# Altium schematic sheet Click Mezzanine_v103.SchDoc("Click Mezzanine_v103")
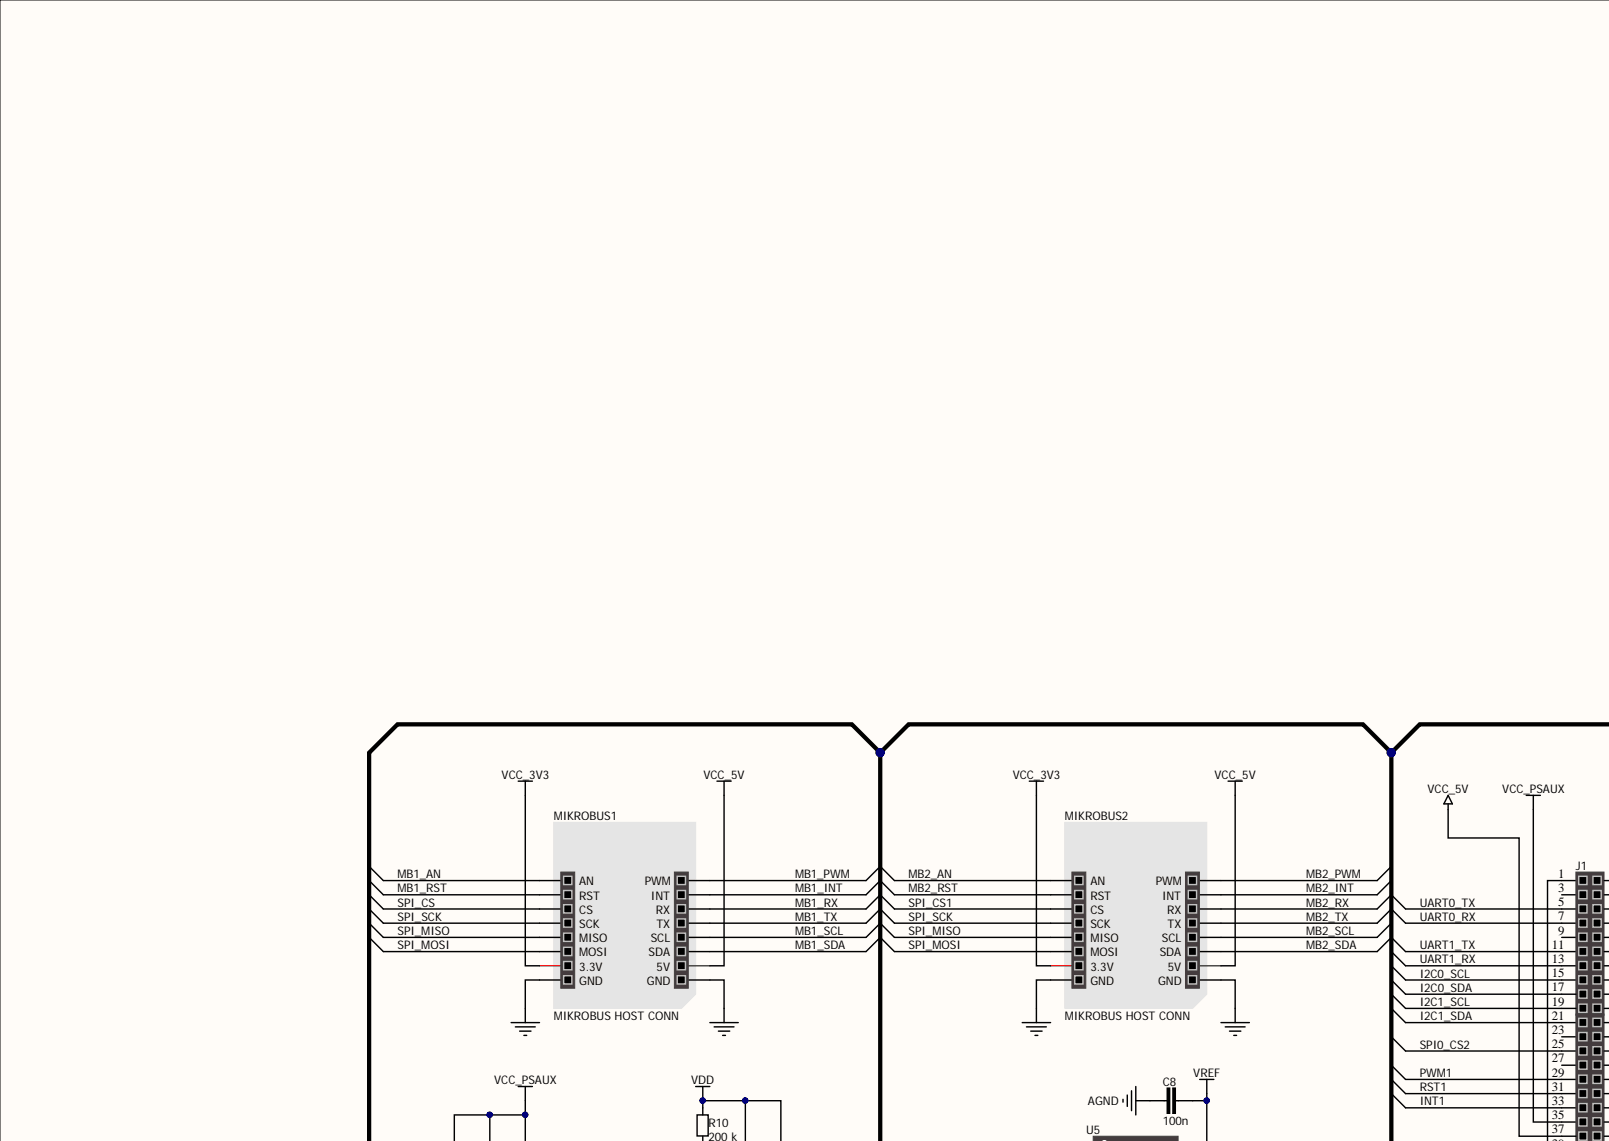
[diagram p0: Click Mezzanine_v103.SchDoc, top left]
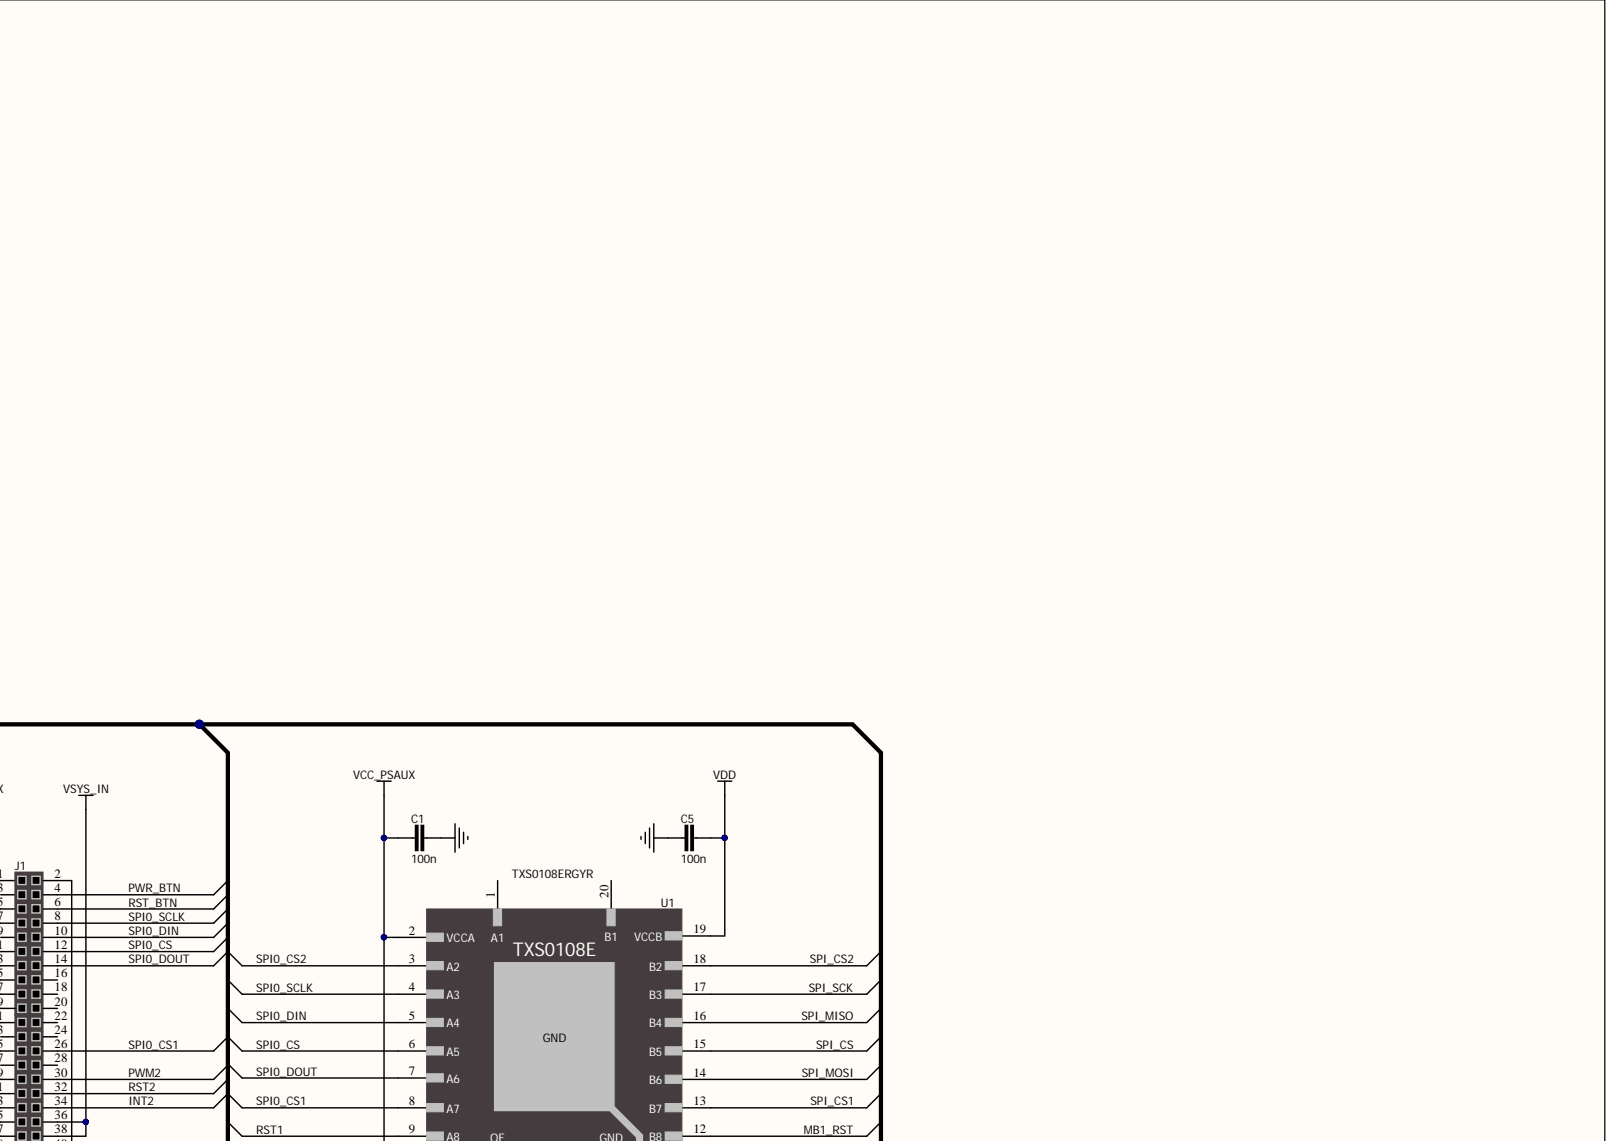
[diagram p1: Click Mezzanine_v103.SchDoc, top right]
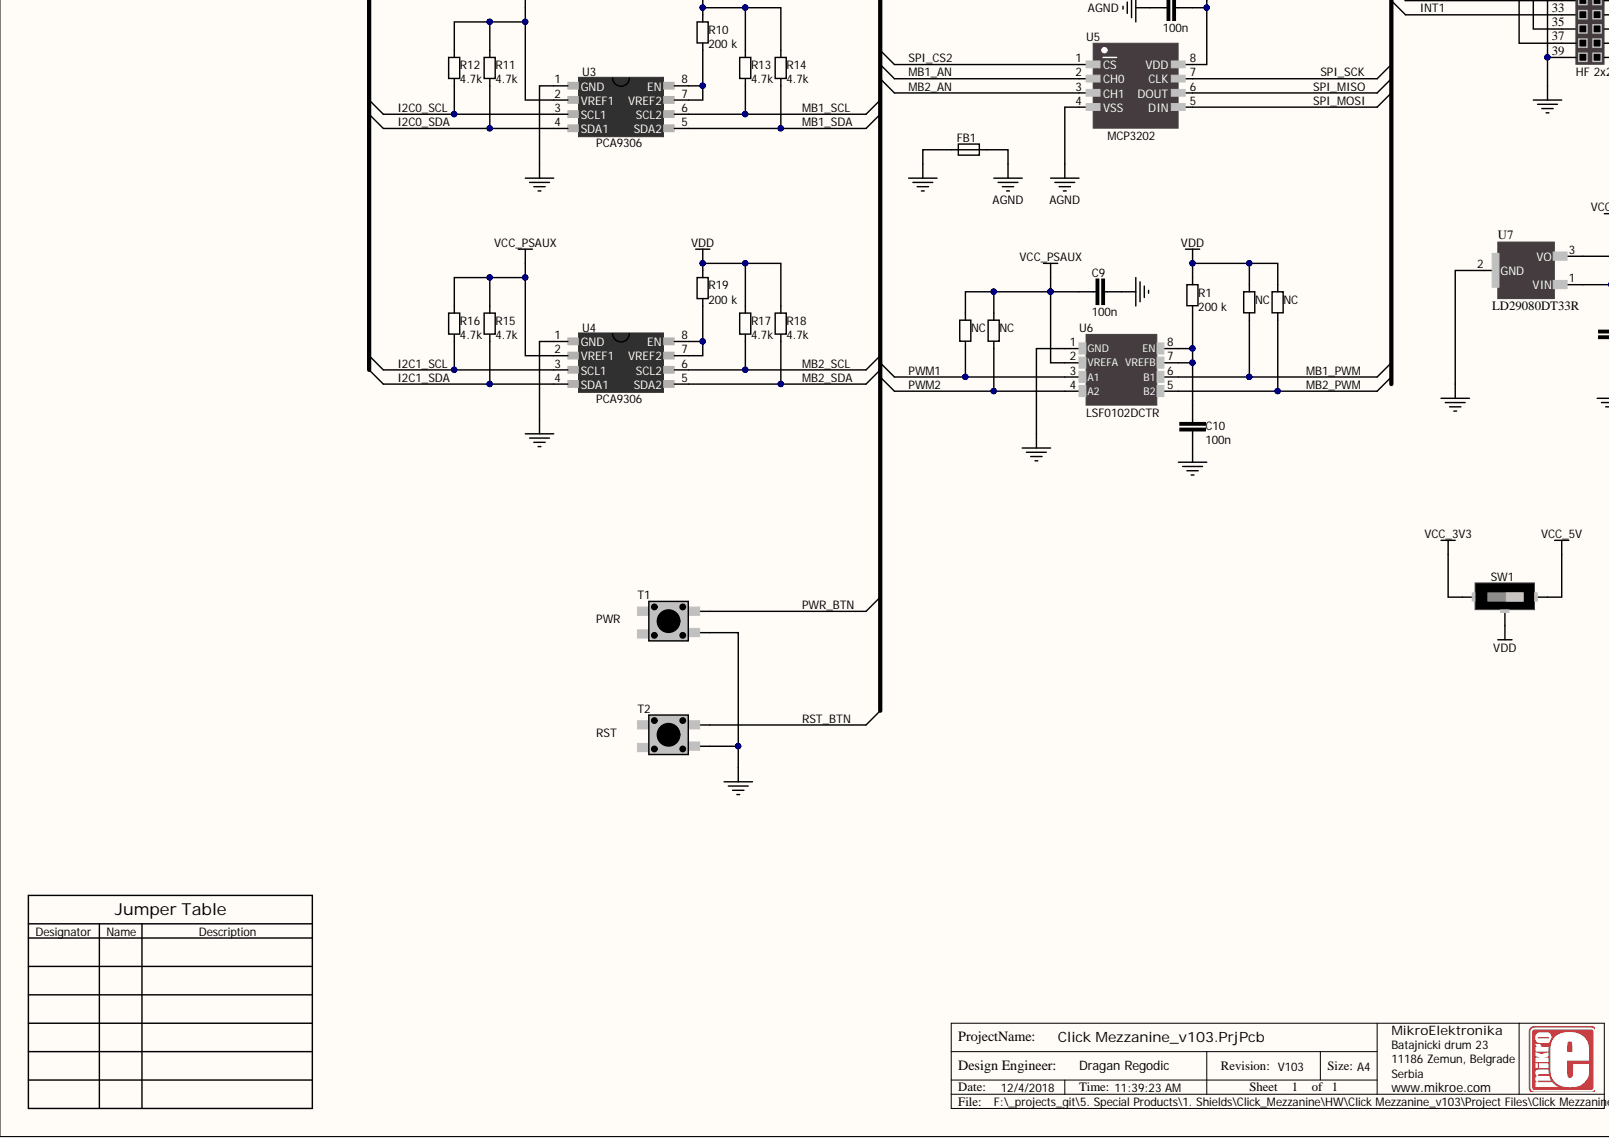
[diagram p2: Click Mezzanine_v103.SchDoc, bottom left]
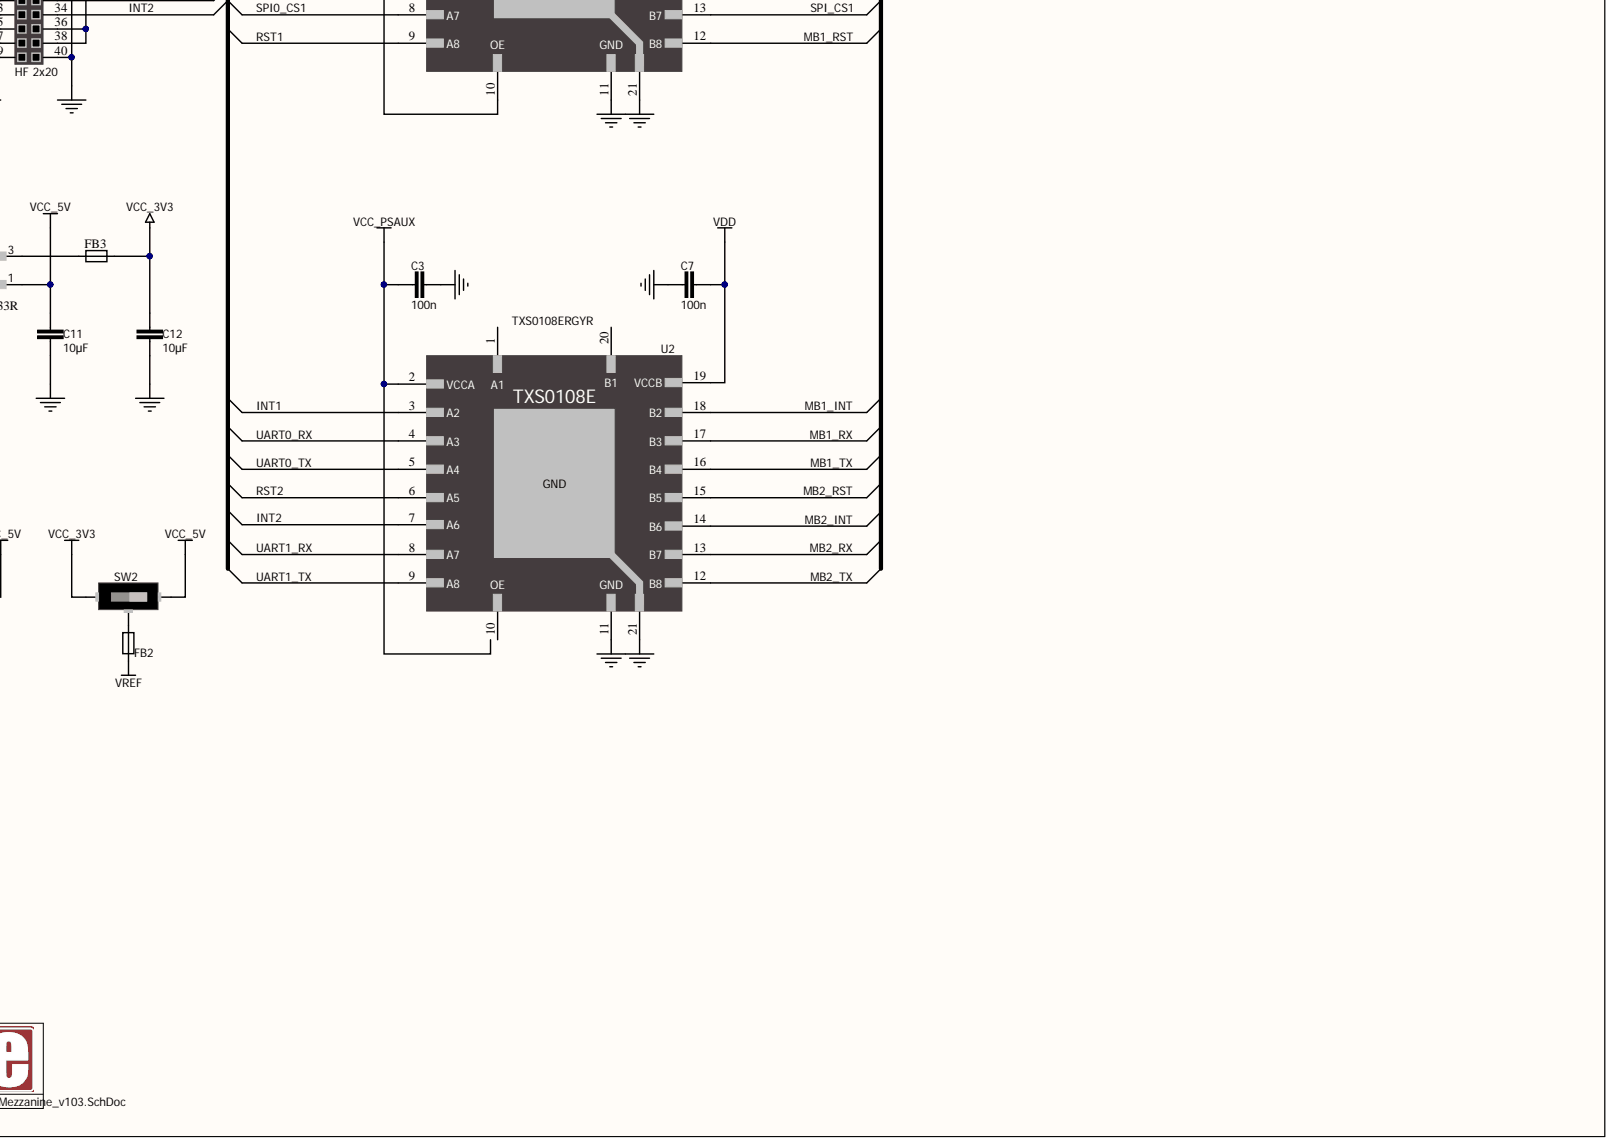
[diagram p3: Click Mezzanine_v103.SchDoc, bottom right]

## Parts
C1  100n  [CAPC2013X100N-0805-NoOverlay]
C3  100n  [CAPC2013X100N-0805-NoOverlay]
C5  100n  [CAPC2013X100N-0805-NoOverlay]
C7  100n  [CAPC2013X100N-0805-NoOverlay]
C8  100n  [CAPC2013X100N-0805-NoOverlay]
C9  100n  [CAPC2013X100N-0805-NoOverlay]
C10  100n  [CAPC2013X100N-0805-NoOverlay]
C11  10µF  [CAPC2013X100N-0805-NoOverlay]
C12  10µF  [CAPC2013X100N-0805-NoOverlay]
FB1  FERRITE  [INDC2213X140N-0805-NOOVERLAY]  (value not drawn)
FB2  FERRITE  [INDC2213X140N-0805-NOOVERLAY]  (value not drawn)
FB3  FERRITE  [INDC2213X140N-0805-NOOVERLAY]  (value not drawn)
J1  HF 2x20  Number of Positions: 2x20  [HF2X20-2MM-STACKING]
MIKROBUS1  MIKROBUS HOST CONN  [MIKROBUS HOST CONN. SMALL SMD V2a]  (no BOM)
MIKROBUS2  MIKROBUS HOST CONN  [MIKROBUS HOST CONN. SMALL SMD V2a]  (no BOM)
R1  200 k  [RESC2013X38N-0805-NOOVERLAY]
R3  NC  [RESC2013X38N-0805-NOOVERLAY]  (no BOM)
R4  NC  [RESC2013X38N-0805-NOOVERLAY]  (no BOM)
R5  NC  [RESC2013X38N-0805-NOOVERLAY]  (no BOM)
R6  NC  [RESC2013X38N-0805-NOOVERLAY]  (no BOM)
R10  200 k  [RESC2013X38N-0805-NOOVERLAY]
R11  4.7k  [RESC2013X38N-0805-NOOVERLAY]
R12  4.7k  [RESC2013X38N-0805-NOOVERLAY]
R13  4.7k  [RESC2013X38N-0805-NOOVERLAY]
R14  4.7k  [RESC2013X38N-0805-NOOVERLAY]
R15  4.7k  [RESC2013X38N-0805-NOOVERLAY]
R16  4.7k  [RESC2013X38N-0805-NOOVERLAY]
R17  4.7k  [RESC2013X38N-0805-NOOVERLAY]
R18  4.7k  [RESC2013X38N-0805-NOOVERLAY]
R19  200 k  [RESC2013X38N-0805-NOOVERLAY]
SW1  CJS-1200TA  [CAS-120TA-NoOverlay]  (value not drawn)
SW2  CJS-1200TA  [CAS-120TA-NoOverlay]  (value not drawn)
T1  PWR  [KMR221NG LFS-NoOverlay]
T2  RST  [KMR221NG LFS-NoOverlay]
U1  TXS0108ERGYR  [QFN50P450X350X100-21_21T215X315N-VFQFN-20-NoOverlay]
U2  TXS0108ERGYR  [QFN50P450X350X100-21_21T215X315N-VFQFN-20-NoOverlay]
U3  PCA9306  [VFSOP-8 NO OVERLAY]
U4  PCA9306  [VFSOP-8 NO OVERLAY]
U5  MCP3202  [SOIC8P127_490X600X175L83X41N-SOIC8 - NoOverlay]
U6  LSF0102DCTR  [SOP65P490X110-8L30N-TSSOP-8-NoOverlay]
U7  LD29080DT33R  [TO230P950X240-3N-NoOverlay]

## Nets
AGND: C8.1 FB1.1 U5.4(VSS)
GND: C1.1 C3.1 C5.1 C7.1 C9.1 C10.2 C11.1 C12.1 FB1.2 J1.1 J1.2 J1.39 J1.40 MIKROBUS1.8(GND) MIKROBUS1.9(GND) MIKROBUS2.8(GND) MIKROBUS2.9(GND) T1.2 T2.2 U1.11 U1.21 U2.11 U2.21 U3.1(GND) U4.1(GND) U6.1(GND) U7.2
VCC_3V3: C12.2 FB3.1 MIKROBUS1.7(3.3V) MIKROBUS2.7(3.3V) SW1.3 SW2.3
VCC_5V: C11.2 J1.37 MIKROBUS1.10(5V) MIKROBUS2.10(5V) SW1.1 SW2.1 U7.1
VCC_PSAUX: C1.2 C3.2 C9.2 J1.35 R3.2 R4.2 R11.2 R12.2 R15.2 R16.2 U1.2(VCCA) U1.10(OE) U2.2(VCCA) U3.2(VREF1) U4.2(VREF1) U6.2(VREFA)
VDD: C5.2 C7.2 R1.2 R5.2 R6.2 R10.2 R13.2 R14.2 R17.2 R18.2 R19.2 SW1.2 U1.19(VCCB) U2.19(VCCB)
VREF: C8.2 FB2.2 U5.8(VDD)
VSYS_IN: J1.36 J1.38
I2C0_SCL: J1.15 R12.1 U3.3(SCL1)
I2C0_SDA: J1.17 R11.1 U3.4(SDA1)
I2C1_SCL: J1.19 R16.1 U4.3(SCL1)
I2C1_SDA: J1.21 R15.1 U4.4(SDA1)
INT1: J1.33 U2.3(A2)
INT2: J1.34 U2.7(A6)
MB1_AN: MIKROBUS1.1(AN) U5.2(CH0)
MB1_INT: MIKROBUS1.15(INT) U2.18(B2)
MB1_PWM: MIKROBUS1.16(PWM) R5.1 U6.6(B1)
MB1_RST: MIKROBUS1.2(RST) U1.12(B8)
MB1_RX: MIKROBUS1.14(RX) U2.17(B3)
MB1_SCL: MIKROBUS1.12(SCL) R13.1 U3.6(SCL2)
MB1_SDA: MIKROBUS1.11(SDA) R14.1 U3.5(SDA2)
MB1_TX: MIKROBUS1.13(TX) U2.16(B4)
MB2_AN: MIKROBUS2.1(AN) U5.3(CH1)
MB2_INT: MIKROBUS2.15(INT) U2.14(B6)
MB2_PWM: MIKROBUS2.16(PWM) R6.1 U6.5(B2)
MB2_RST: MIKROBUS2.2(RST) U2.15(B5)
MB2_RX: MIKROBUS2.14(RX) U2.13(B7)
MB2_SCL: MIKROBUS2.12(SCL) R17.1 U4.6(SCL2)
MB2_SDA: MIKROBUS2.11(SDA) R18.1 U4.5(SDA2)
MB2_TX: MIKROBUS2.13(TX) U2.12(B8)
PWM1: J1.29 R3.1 U6.3(A1)
PWM2: J1.30 R4.1 U6.4(A2)
PWR_BTN: J1.4 T1.1
RST1: J1.31 U1.9(A8)
RST2: J1.32 U2.6(A5)
RST_BTN: J1.6 T2.1
SPI0_CS: J1.12 U1.6(A5)
SPI0_CS1: J1.26 U1.8(A7)
SPI0_CS2: J1.25 U1.3(A2)
SPI0_DIN: J1.10 U1.5(A4)
SPI0_DOUT: J1.14 U1.7(A6)
SPI0_SCLK: J1.8 U1.4(A3)
SPI_CS: MIKROBUS1.3(CS) U1.15(B5)
SPI_CS1: MIKROBUS2.3(CS) U1.13(B7)
SPI_CS2: U1.18(B2) U5.1(CS)
SPI_MISO: MIKROBUS1.5(MISO) MIKROBUS2.5(MISO) U1.16(B4) U5.6(DOUT)
SPI_MOSI: MIKROBUS1.6(MOSI) MIKROBUS2.6(MOSI) U1.14(B6) U5.5(DIN)
SPI_SCK: MIKROBUS1.4(SCK) MIKROBUS2.4(SCK) U1.17(B3) U5.7(CLK)
UART0_RX: J1.7 U2.4(A3)
UART0_TX: J1.5 U2.5(A4)
UART1_RX: J1.13 U2.8(A7)
UART1_TX: J1.11 U2.9(A8)
NetC10_1: C10.1 R1.1 U6.7(VREFB) U6.8(EN)
NetFB2_1: FB2.1 SW2.2
NetFB3_2: FB3.2 U7.3
NetR10_1: R10.1 U3.7(VREF2) U3.8(EN)
NetR19_1: R19.1 U4.7(VREF2) U4.8(EN)
N00045:

Not connected: J1 3 9 16 18 20 22 23 24 27 28; U1 1(A1) 20(B1); U2 1(A1) 10(OE) 20(B1)

## Library data of the parts
C1 C3 C5 C7-C10 = 0100000001868: Manufacturer: Yageo; Manufacturer Part Number: CC0805KRX7R9BB104; Assembly: SMD; Database Table Name: capacitors; id: 136; item_id: 450; Recommended Rating: Platinum; Temperature Coefficient: X7R; Tolerance: 10%; Voltage Rated: 50; Barcode: 0100000001868; Capacitance: 100n; Category: Capacitors; Component Kind: Standard; Family: CERAMIC CAPACITOR; Library Name: ME-Altium Lib.SVNDbLib; Package Case: 0805; Part Type: Capacitors
C11 C12 = 0100000012963: CAP CER 10UF 25V X5R 0805; Manufacturer: Murata Electronics North America; Manufacturer Part Number: GRM21BR61E106KA73L; Assembly: SMD; Database Table Name: capacitors; id: 376; item_id: 1714; Recommended Rating: Platinum; Tolerance: ±10%; Barcode: 0100000012963; Capacitance: 10µF; Category: Capacitors; Component Kind: Standard; Datasheet URL: http://www.murata.com/~/media/webrenewal/support/library/catalog/products/capacitor/mlcc/c02e.ashx; Family: CERAMIC CAPACITOR; Library Name: ME-Altium Lib.SVNDbLib; Package Case: 0805 (2012 Metric); Part Type: Capacitors; Temperature Coefficient: X5R; Voltage Rated: 25V
FB1 FB2 = 0100000107881: FERRITE BEAD 1 KOHM 0805 1LN; Manufacturer: Murata Electronics North America; Manufacturer Part Number: BLM21AG102SN1D; Assembly: SMD; Database Table Name: inductor_transf_filter_ferrite; id: 84; item_id: 9902; Barcode: 0100000107881; Category: Filters; Component Kind: Standard; Datasheet URL: http://search.murata.co.jp/Ceramy/image/img/PDF/ENG/L0110S0100BLM21A.pdf; Family: FERRITE; Filter Type: -; Library Name: ME-Altium Lib.SVNDbLib; Package Case: 0805 (2012 Metric); Part Type: Inductor, Transf, Filter, Ferrite
FB3 = 0100000083673: BEAD FERRITE 30 OHM 3A; Manufacturer: Bourns Inc.; Manufacturer Part Number: MH2029-300Y; Assembly: SMD; Database Table Name: inductor_transf_filter_ferrite; DC Resistance: 25 mOhm Max; Filter Type: Differential Mode - Single; id: 46; Impedance at Frequency: 30 Ohm @ 100MHz; item_id: 7482; Barcode: 0100000083673; Category: Filters; Component Kind: Standard; Current Rating: 3A; Datasheet URL: http://www.bourns.com/docs/Product-Datasheets/mh.pdf; Family: FERRITE; Library Name: SVNDatabase.SVNDbLib; Package Case: 0805 (2012 Metric); Part Type: Inductor, Transf, Filter, Ferrite
J1 = 3500000191700: Manufacturer: Yxcon; Manufacturer Part Number: F243-1220xxxSUx1; Assembly: TH; Connector Type: STACKING SOCKET; Database Table Name: connectors_electromechanical; id: 736; item_id: 17307; Pitch: 2mm; Barcode: 3500000191700; Component Kind: Standard; Family: FEMALE SOCKET; Library Name: ME-Altium Lib.SVNDbLib; Number of Rows: 2; Part Type: Connectors, Electromechanical
MIKROBUS1 MIKROBUS2 = MIKROBUS HOST CONN: mikroBUS Host Socket; Library Name: ME-Integrated_Library.IntLib; SMD: No
R1 R10 R19 = 3500000162588: RES SMD 200K OHM 1% 1/8W 0805; Manufacturer: Yageo; Manufacturer Part Number: RC0805FR-07200KL; Database Table Name: resistors; id: 849; item_id: 14394; Power: 0.125W, 1/8W; Temperature Coefficient: ±100ppm/°C; Assembly: SMD; Barcode: 3500000162588; Category: Resistors; Component Kind: Standard; Datasheet URL: http://www.yageo.com/documents/recent/PYu-RC_Group_51_RoHS_L_7.pdf; Family: RESISTOR; Library Name: ME-Altium Lib.SVNDbLib; Package Case: 0805 (2012 Metric); Part Type: Resistors; Resistance: 200 k; Tolerance: ±1%
R3-R6 = 0100000007921: RES SMD 470 OHM 1% 1/8W 0805; Manufacturer: Yageo; Manufacturer Part Number: RC0805FR-07470RL; Database Table Name: resistors; id: 207; item_id: 1082; Power: 0.125W, 1/8W; Recommended Rating: Platinum; Resistance: 470; Temperature Coefficient: ±100ppm/°C; Assembly: SMD; Barcode: 0100000007921; Category: Resistors; Datasheet URL: http://www.yageo.com/NewPortal/yageodocoutput?fileName=/pdf/R-Chip/PYu-RC_Group_51_RoHS_L_3.pdf; Family: RESISTOR; Library Name: ME-Altium Lib.SVNDbLib; Package Case: 0805 (2012 Metric); Part Type: Resistors; Tolerance: ±1%
R11-R18 = 0100000002056: RES SMD 4.7K OHM 1% 1/8W 0805; Manufacturer: Yageo; Manufacturer Part Number: RC0805FR-074K7L; Database Table Name: resistors; id: 221; item_id: 470; Power: 0.125W, 1/8W; Recommended Rating: Platinum; Temperature Coefficient: ±100ppm/°C; Assembly: SMD; Barcode: 0100000002056; Category: Resistors; Component Kind: Standard; Datasheet URL: http://www.yageo.com/NewPortal/yageodocoutput?fileName=/pdf/R-Chip/PYu-RC_Group_51_RoHS_L_3.pdf; Family: RESISTOR; Library Name: ME-Altium Lib.SVNDbLib; Package Case: 0805 (2012 Metric); Part Type: Resistors; Resistance: 4.7k; Tolerance: ±1%
SW1 SW2 = 3500000160485: SWITCH SLIDE SPDT 100MA 6V; Manufacturer: Copal Electronics Inc.; Assembly: SMD; Current Rating: 100mA (DC); Database Table Name: connectors_electromechanical; id: 567; item_id: 14184; Switch Function: On-On; Barcode: 3500000160485; Category: Switches; Circuit: SPDT; Component Kind: Standard; Contact Finish: Gold; Datasheet URL: https://www.nidec-copal-electronics.com/e/catalog/switch/cjs.pdf; Family: SWITCH SLIDE; Features: Tape Seal; Library Name: ME-Altium Lib.SVNDbLib; Manufacturer Part Number: CJS-1200TA; Mounting Type: Surface Mount; Part Type: Connectors, Electromechanical
T1 T2 = 2911010042202: SWITCH TACTILE SPST-NO 0.05A 32V; Manufacturer: C&K Components; Manufacturer Part Number: KMR221NG LFS; Assembly: SMD; Contact Rating Voltage: 0.05A @ 32VDC; Database Table Name: connectors_electromechanical; id: 87; item_id: 4900; Barcode: 2911010042202; Category: Switches; Circuit: SPST-NO; Component Kind: Standard; Datasheet URL: http://www.ck-components.com/index.php?module=media&action=Display&cmpref=14414&lang=en&width=&height=&format=&alt=; Family: SWITCH TACTILE; Features: -; Library Name: ME-Altium Lib.SVNDbLib; Mounting Type: Surface Mount; Part Type: Connectors, Electromechanical
U1 U2 = 3500000157188: IC XLATR VOLT-LVL 8B BDIR 20QFN; Manufacturer: Texas Instruments; Assembly: SMD; Channel Type: Bidirectional; Database Table Name: integrated_circuits; Data Rate: 60Mbps; id: 1483; item_id: 13854; Output Type: Open Drain, Push-Pull; Translator Type: Voltage Level; Barcode: 3500000157188; Category: Integrated Circuits (ICs); Component Kind: Standard; Datasheet URL: http://www.ti.com/lit/ds/symlink/txs0108e.pdf; Family: Translators, Level Shifters; Features: Auto-Direction Sensing; Library Name: ME-Altium Lib.SVNDbLib; Manufacturer Part Number: TXS0108ERGYR; Package Case: 20-VFQFN Exposed Pad; Part Type: Integrated Circuits
U3 U4 = 2911010042295: IC VOLT LEVEL TRANSLATOR US8; Manufacturer: Texas Instruments; Manufacturer Part Number: PCA9306DCUR; Assembly: SMD; Channel Type: Bidirectional; Database Table Name: integrated_circuits; id: 131; item_id: 4909; Output Type: Open Drain, Push-Pull; Translator Type: Voltage Level; Voltage  VCCA: 1.2 V ~ 3.3 V; Voltage  VCCB: 1.8 V ~ 5.5 V; Barcode: 2911010042295; Category: Integrated Circuits (ICs); Component Kind: Standard; Datasheet URL: http://www.ti.com/lit/ds/symlink/pca9306.pdf; Family: Translators, Level Shifters; Features: Auto-Direction Sensing; Library Name: ME-Altium Lib.SVNDbLib; Package Case: 8-VFSOP (0.091", 2.30mm Width); Part Type: Integrated Circuits
U5 = 0100000113820: IC ADC 12BIT DUAL CHAN 8SOIC; Manufacturer: Microchip Technology; Manufacturer Part Number: MCP3202-CI/SN; Architecture: SAR; Assembly: SMD; Configuration: S/H-ADC; Database Table Name: integrated_circuits; Data Interface: SPI; id: 883; Input Type: Pseudo-Differential, Single Ended; item_id: 10496; Number of Bits: 12; Reference Type: External; Voltage  Supply Analog: 2.7 V ~ 5.5 V; Barcode: 0100000113820; Category: Integrated Circuits (ICs); Component Kind: Standard; Datasheet URL: http://www.microchip.com/mymicrochip/filehandler.aspx?ddocname=en011281; Family: Analog to Digital Converters (ADC); Features: -; Library Name: ME-Altium Lib.SVNDbLib; Package Case: 8-SOIC (0.154", 3.90mm Width); Part Type: Integrated Circuits; Voltage  Supply Digital: 2.7 V ~ 5.5 V
U6 = 3500000178510: IC LEVEL TRANSLATOR BIDIR 8SM; Manufacturer: Texas Instruments; Assembly: SMD; Channel Type: Bidirectional; Database Table Name: integrated_circuits; id: 1778; item_id: 15986; Output Type: Open Drain, Push-Pull; Translator Type: Voltage Level; Voltage  VCCA: 0.95V ~ 4.5V; Voltage  VCCB: 1.8V ~ 5.5V; Barcode: 3500000178510; Category: Integrated Circuits (ICs); Component Kind: Standard; Data Rate: -; Datasheet URL: http://www.ti.com/lit/ds/symlink/lsf0102.pdf; Family: Translators, Level Shifters; Features: Auto-Direction Sensing; Library Name: ME-Altium Lib.SVNDbLib; Manufacturer Part Number: LSF0102DCTR; Package Case: 8-LSSOP, 8-MSOP (0.110", 2.80mm Width); Part Type: Integrated Circuits
U7 = 2911010012854: IC REG LDO 3.3V 0.8A DPAK; Manufacturer: STMicroelectronics; Assembly: SMD; Current  Output: 800mA; Database Table Name: integrated_circuits; id: 257; item_id: 2338; Voltage  Input: Up to 13V; Barcode: 2911010012854; Category: Integrated Circuits (ICs); Component Kind: Standard; Datasheet URL: http://www.st.com/web/en/resource/technical/document/datasheet/CD00044919.pdf; Family: Voltage Regulators - Linear; Library Name: SVNDatabase.SVNDbLib; Manufacturer Part Number: LD29080DT33R; Package Case: TO-252-3, DPak (2 Leads + Tab), SC-63; Part Type: Integrated Circuits; Voltage  Output: 3.3V
